# Altium schematic sheet POWER.SchDoc
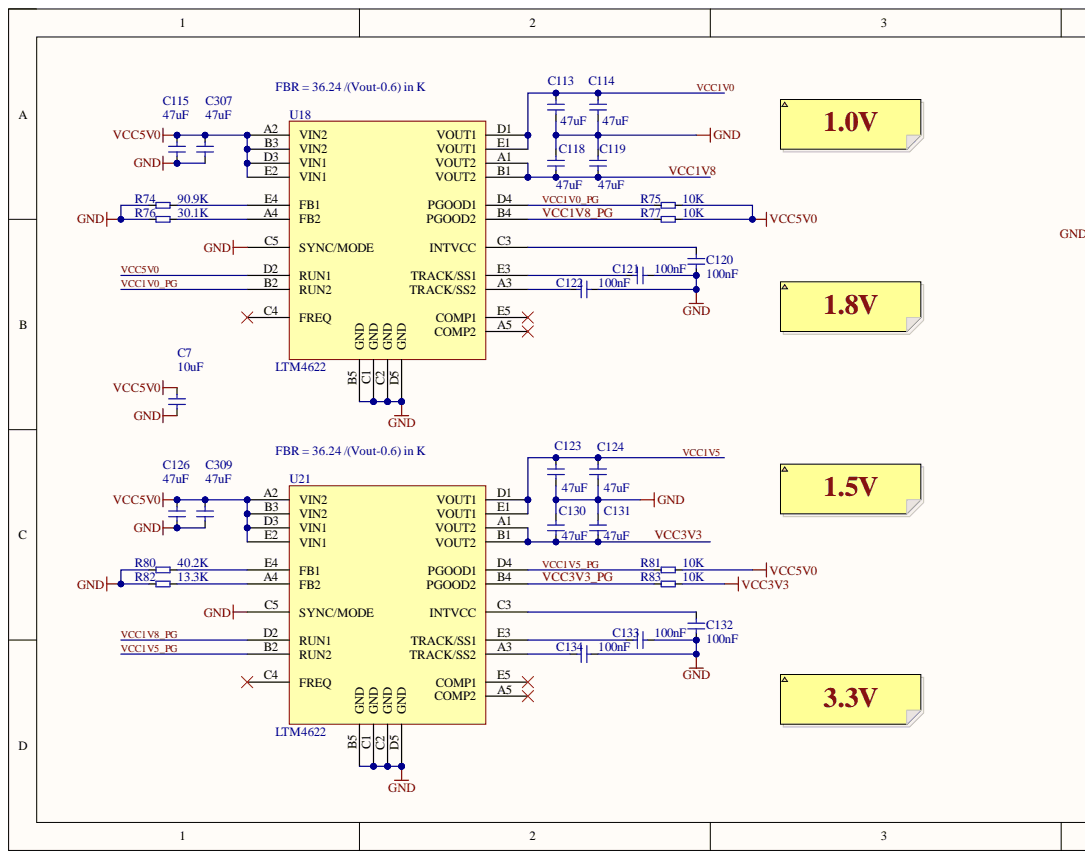
[diagram p0: POWER.SchDoc, left]
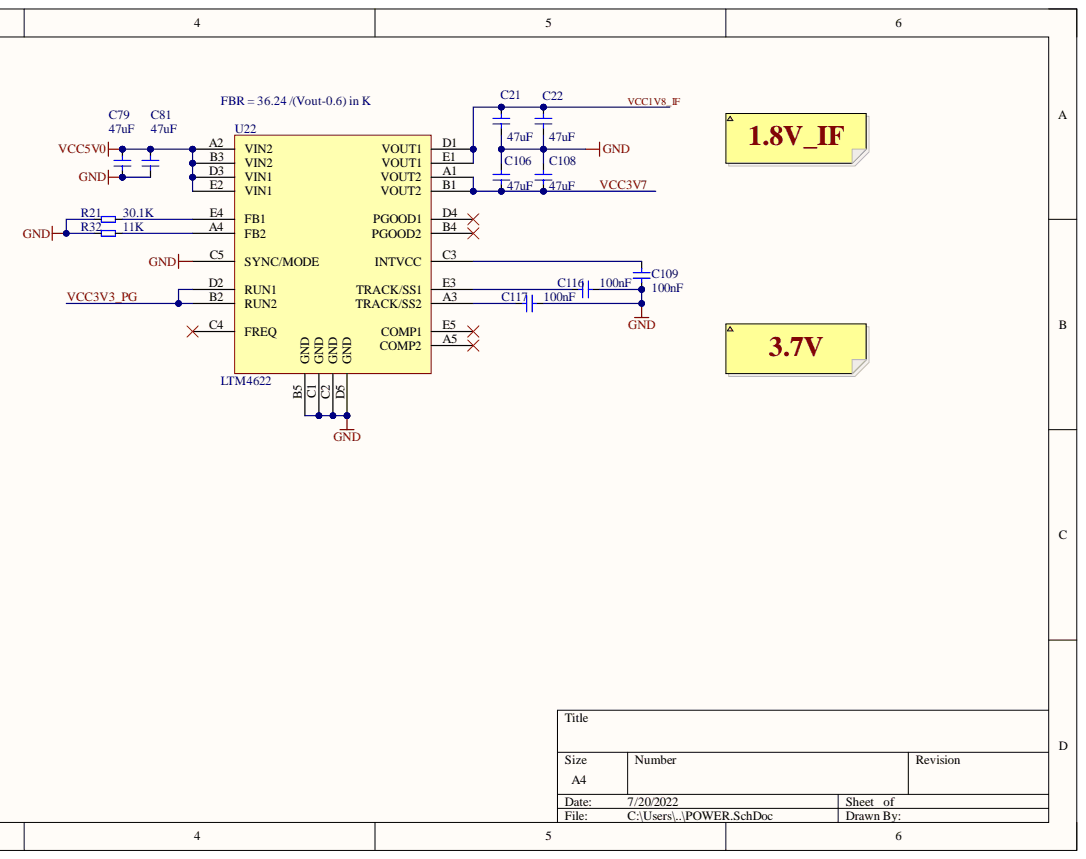
[diagram p1: POWER.SchDoc, right]

## Parts
C7  10uF  [C0603]
C21  47uF  [C0805]
C22  47uF  [C0805]
C79  47uF  [C0805]
C81  47uF  [C0805]
C106  47uF  [C0805]
C108  47uF  [C0805]
C109  100nF  [C0201]
C113  47uF  [C0805]
C114  47uF  [C0805]
C115  47uF  [C0805]
C116  100nF  [C0201]
C117  100nF  [C0201]
C118  47uF  [C0805]
C119  47uF  [C0805]
C120  100nF  [C0201]
C121  100nF  [C0201]
C122  100nF  [C0201]
C123  47uF  [C0805]
C124  47uF  [C0805]
C126  47uF  [C0805]
C130  47uF  [C0805]
C131  47uF  [C0805]
C132  100nF  [C0201]
C133  100nF  [C0201]
C134  100nF  [C0201]
C307  47uF  [C0805]
C309  47uF  [C0805]
R21  30.1K  [R0201]
R32  11K  [R0201]
R74  90.9K  [R0201]
R75  10K  [R0201]
R76  30.1K  [R0201]
R77  10K  [R0201]
R80  40.2K  [R0201]
R81  10K  [R0201]
R82  13.3K  [R0201]
R83  10K  [R0201]
U18  LTM4622  [LGA25C127P5X5_625X625X192]
U21  LTM4622  [LGA25C127P5X5_625X625X192]
U22  LTM4622  [LGA25C127P5X5_625X625X192]

## Nets
GND: C7.1 C21.1 C22.1 C79.1 C81.1 C106.1 C108.1 C109.1 C113.1 C114.1 C115.1 C116.2 C117.2 C118.1 C119.1 C120.1 C121.2 C122.2 C123.1 C124.1 C126.1 C130.1 C131.1 C132.1 C133.2 C134.2 C307.1 C309.1 R21.1 R32.1 R74.1 R76.1 R80.1 R82.1 U18.B5(GND) U18.C1(GND) U18.C2(GND) U18.C5(SYNC/MODE) U18.D5(GND) U21.B5(GND) U21.C1(GND) U21.C2(GND) U21.C5(SYNC/MODE) U21.D5(GND) U22.B5(GND) U22.C1(GND) U22.C2(GND) U22.C5(SYNC/MODE) U22.D5(GND)
VCC1V0: C113.2 C114.2 U18.D1(VOUT1) U18.E1(VOUT1)
VCC1V0_PG: R75.1 U18.B2(RUN2) U18.D4(PGOOD1)
VCC1V5: C123.2 C124.2 U21.D1(VOUT1) U21.E1(VOUT1)
VCC1V5_PG: R81.1 U21.B2(RUN2) U21.D4(PGOOD1)
VCC1V8: C118.2 C119.2 U18.A1(VOUT2) U18.B1(VOUT2)
VCC1V8_IF: C21.2 C22.2 U22.D1(VOUT1) U22.E1(VOUT1)
VCC1V8_PG: R77.1 U18.B4(PGOOD2) U21.D2(RUN1)
VCC3V3: C130.2 C131.2 R83.2 U21.A1(VOUT2) U21.B1(VOUT2)
VCC3V3_PG: R83.1 U21.B4(PGOOD2) U22.B2(RUN2) U22.D2(RUN1)
VCC3V7: C106.2 C108.2 U22.A1(VOUT2) U22.B1(VOUT2)
VCC5V0: C7.2 C79.2 C81.2 C115.2 C126.2 C307.2 C309.2 R75.2 R77.2 R81.2 U18.A2(VIN2) U18.B3(VIN2) U18.D2(RUN1) U18.D3(VIN1) U18.E2(VIN1) U21.A2(VIN2) U21.B3(VIN2) U21.D3(VIN1) U21.E2(VIN1) U22.A2(VIN2) U22.B3(VIN2) U22.D3(VIN1) U22.E2(VIN1)
NetC109_2: C109.2 U22.C3(INTVCC)
NetC116_1: C116.1 U22.E3(TRACK/SS1)
NetC117_1: C117.1 U22.A3(TRACK/SS2)
NetC120_2: C120.2 U18.C3(INTVCC)
NetC121_1: C121.1 U18.E3(TRACK/SS1)
NetC122_1: C122.1 U18.A3(TRACK/SS2)
NetC132_2: C132.2 U21.C3(INTVCC)
NetC133_1: C133.1 U21.E3(TRACK/SS1)
NetC134_1: C134.1 U21.A3(TRACK/SS2)
NetR21_2: R21.2 U22.E4(FB1)
NetR32_2: R32.2 U22.A4(FB2)
NetR74_2: R74.2 U18.E4(FB1)
NetR76_2: R76.2 U18.A4(FB2)
NetR80_2: R80.2 U21.E4(FB1)
NetR82_2: R82.2 U21.A4(FB2)

Not connected: U18 A5(COMP2) C4(FREQ) E5(COMP1); U21 A5(COMP2) C4(FREQ) E5(COMP1); U22 A5(COMP2) B4(PGOOD2) C4(FREQ) D4(PGOOD1) E5(COMP1)

## Library data of the parts
C7 C21 C22 C79 C81 C106 C108 C109 C113-C124 C126 C130-C134 C307 C309 = C: Capacitor; Component Kind: Standard; Library Name: 电容-SCH.LIB
R21 R32 R74-R77 R80-R83 = RES2: Component Kind: Standard; Library Name: 电阻-SCH.LIB
U18 U21 U22 = LTM4622: Component Kind: Standard; Library Name: Schlib1.SchLib
